# Altium schematic sheet Z12 - Neopixel Board V2.SchDoc("Z12 - Neopixel Board V2")
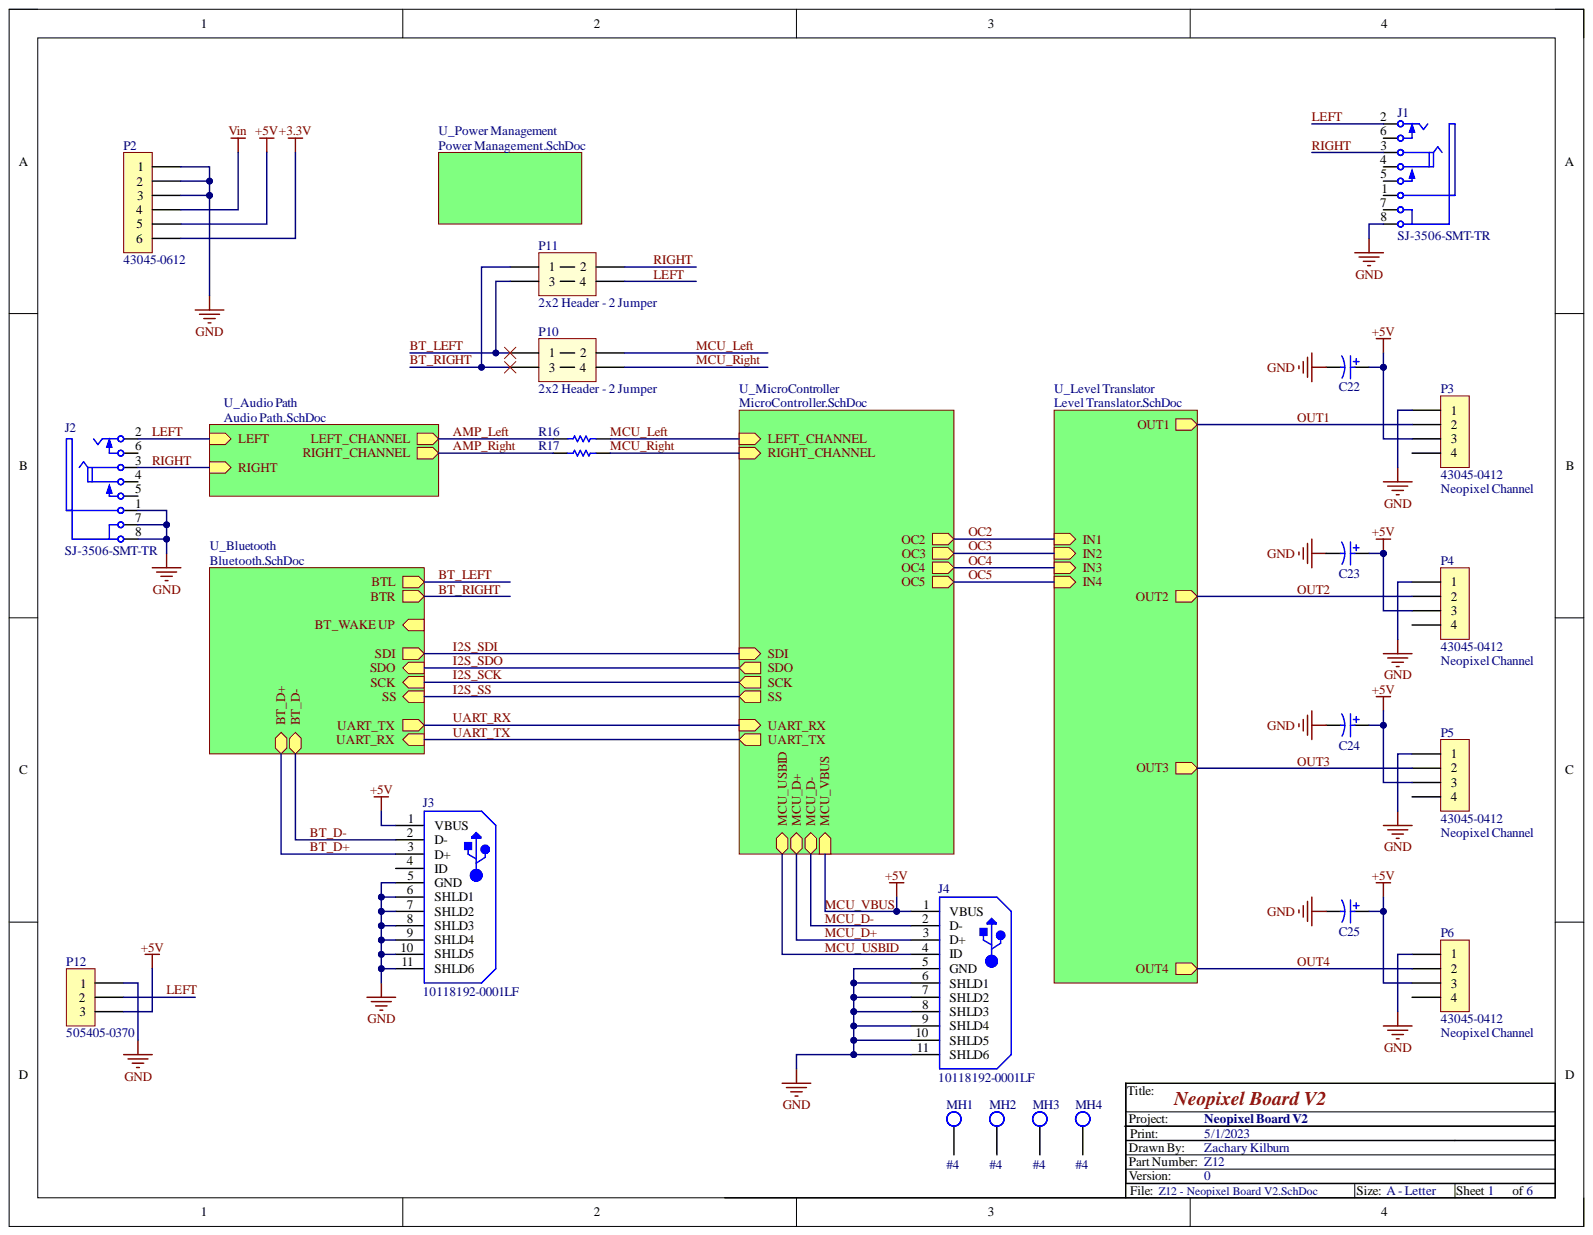
[diagram p0: Z12 - Neopixel Board V2.SchDoc]

## Parts
C22  T495D107K010ATE100  [PCC-KEMT-T495-D-RN]  (value not drawn)
C23  T495D107K010ATE100  [PCC-KEMT-T495-D-RN]  (value not drawn)
C24  T495D107K010ATE100  [PCC-KEMT-T495-D-RN]  (value not drawn)
C25  T495D107K010ATE100  [PCC-KEMT-T495-D-RN]  (value not drawn)
J1  SJ-3506-SMT-TR  [CUI-SJ-3506-SMT-TR_V]
J2  SJ-3506-SMT-TR  [CUI-SJ-3506-SMT-TR_V]
J3  10118192-0001LF  [FCI-10118192-0001LF-5_V]
J4  10118192-0001LF  [FCI-10118192-0001LF-5_V]
MH1  #4  [PCC-XXX-MH-#4-ROUND-PLATED-H128P230M290]  (no BOM)
MH2  #4  [PCC-XXX-MH-#4-ROUND-PLATED-H128P230M290]  (no BOM)
MH3  #4  [PCC-XXX-MH-#4-ROUND-PLATED-H128P230M290]  (no BOM)
MH4  #4  [PCC-XXX-MH-#4-ROUND-PLATED-H128P230M290]  (no BOM)
P2  43045-0612  [PCC-MOLX-43045-0612-R]
P3  43045-0412  Name: Neopixel Channel  [PCC-MOLX-43045-0412-R]
P4  43045-0412  Name: Neopixel Channel  [PCC-MOLX-43045-0412-R]
P5  43045-0412  Name: Neopixel Channel  [PCC-MOLX-43045-0412-R]
P6  43045-0412  Name: Neopixel Channel  [PCC-MOLX-43045-0412-R]
P10  2x2 Header - 2 Jumper  [2x2 PIN 0.1" - 2x Jumper]
P11  2x2 Header - 2 Jumper  [2x2 PIN 0.1" - 2x Jumper]
P12  505405-0370  [PCC-MOLX-505405-0370-R]
R16  Jumper 0603(1608)  [RESC0603(1608)_N]  (value not drawn)
R17  Jumper 0603(1608)  [RESC0603(1608)_N]  (value not drawn)

## Nets
+3.3V: P2.6
GND: C22.2 C23.2 C24.2 C25.2 J1.8 J2.1 J2.7 J2.8 J3.5(GND) J3.6(SHLD1) J3.7(SHLD2) J3.8(SHLD3) J3.9(SHLD4) J3.10(SHLD5) J3.11(SHLD6) J4.5(GND) J4.6(SHLD1) J4.7(SHLD2) J4.8(SHLD3) J4.9(SHLD4) J4.10(SHLD5) J4.11(SHLD6) P2.1 P2.2 P2.3 P3.1 P4.1 P5.1 P6.1 P12.1
Vin: P2.4
+5V: C22.1 C23.1 C24.1 C25.1 J3.1(VBUS) J4.1(VBUS) P2.5 P3.3 P4.3 P5.3 P6.3 P12.3
AMP_Left: R16.1
AMP_Right: R17.1
BT_D+: J3.3(D+)
BT_D-: J3.2(D-)
BT_LEFT: P10.1 P11.3
BT_RIGHT: P10.3 P11.1
LEFT: J1.2 J2.2 P11.4 P12.2
MCU_D+: J4.3(D+)
MCU_D-: J4.2(D-)
MCU_Left: P10.2 R16.2
MCU_Right: P10.4 R17.2
MCU_USBID: J4.4(ID)
OUT1: P3.2
OUT2: P4.2
OUT3: P5.2
OUT4: P6.2
RIGHT: J1.3 J2.3 P11.2
NetJ1_1: J1.1
NetJ1_4: J1.4
NetJ1_5: J1.5
NetJ1_6: J1.6
NetJ1_7: J1.7
NetJ2_4: J2.4
NetJ2_5: J2.5
NetJ2_6: J2.6
NetJ3_4: J3.4(ID)
NetMH1_1: MH1.1
NetMH2_1: MH2.1
NetMH3_1: MH3.1
NetMH4_1: MH4.1
NetP3_4: P3.4
NetP4_4: P4.4
NetP5_4: P5.4
NetP6_4: P6.4
BT_WAKE UP:
I2S_SCK:
I2S_SDI:
I2S_SDO:
I2S_SS:
OC2:
OC3:
OC4:
OC5:
UART_RX:
UART_TX:

## Library data of the parts
C22-C25 = CMP-000480-0: Capacitor, Tantalum, 100µF, 10V, SMD, Case Code D; Manufacturer: Kemet; PackingType: Cut Tape; Component Kind: Standard; Library Name: Capacitors.SchLib; PartNumber: T495D107K010ATE100
J1 J2 = CMP-1692-00003-1: Female Mid Mount Audio Jack, 6 Position, Height 2.55 mm, Tail Length 2 mm, -25 to 85 degC, RoHS, Tape and Reel; Current Rating: 7 A; Impedance: 50 Ohm; Case/Package: Axial; Component Kind: Standard; ComponentLink1Description: Manufacturer URL; ComponentLink1URL: http://www.cui.com/; ComponentLink2Description: Datasheet; ComponentLink2URL: http://www.cui.com/product/resource/sj-3506-smt.pdf; DatasheetVersion: Rev. 1.01, 11/2012; Diameter: 3.6 mm; Height: 3.6 mm; Length: 5 mm; Library Name: Altium Content Vault; Max Operating Temperature: 85degC; Min Operating Temperature: -40degC; Mount: Through Hole; Package Quantity: 500; PackageDescription: Female Mid Mount Audio Jack, 6 Position, Height 2.55 mm, Tail Length 2 mm; PackageReference: SJ-3506-SMT-TR; Packaging: Bulk; Pins: 2; Radiation Hardening: No; REACH SVHC: No SVHC; RoHSCompliant: True; Width: 3.6 mm
J3 J4 = CMP-2000-05118-1: Micro USB B Type Receptacle, -55 to 85 degC, 5-Pin SMD, RoHS, Tape and Reel; Brand: FCI; Connector Type: MicroUSBTypeBreceptacle; Current Rating: 1.8A; Gender: Female; Insulation Resistance: 1000MOhms; Mounting Angle: Right; Number of Contacts: 5Contact; Color: Black; Component Kind: Standard; Contact Material: CopperAlloy; Contact Plating: Gold; Datasheet URL: http://www.mouser.com/ds/2/154/10118192-793027.pdf; Datasheet Version: Rev. B, 05/2015; Factory Pack Quantity: 1400; Flammability Rating: UL94V-0; Library Name: Altium Content Vault; Manufacturer: FCI; Manufacturer URL: http://www.fci.com/; Operating Temperature Range: -55Cto+85C; Packaging: Reel; Product: MicroUSBTypeBConnectors; Product Category: USBConnectors; RoHS: Details; Standard: USB2.0; Termination Style: SMD/SMT
MH1-MH4 = CMP-000163-2: #4 Mounting Hole, Round, Plated, No Vias; Units: Imperial; Library Name: MountingHoleImperial.SchLib; Size: #4
P2 = CMP-000056-0: Molex Micro-Fit 3.0 Connector, Dual-Row, 6-Position, Vertical, 3mm Pitch; Current: 5A; MatingStyle: Plug; Positions: 6; Rows: 2; Voltage: 250V; Component Kind: Standard; ComponentLink1Description: Manufacturer URL; ComponentLink1URL: http://www.molex.com; ComponentLink2Description: Product URL; ComponentLink2URL: http://www.molex.com/molex/products/datasheet.jsp?part=active/0430450612_PCB_HEADERS.xml; ComponentLink3Description: Datasheet; ComponentLink3URL: http://www.molex.com/pdm_docs/ps/PS-43045.pdf; ComponentLink4Description: Package Specification; ComponentLink4URL: http://www.molex.com/pdm_docs/sd/430450612_sd.pdf; HelpURL: http://www.molex.com/pdm_docs/sd/430450612_sd.pdf; Library Name: Connectors.SchLib; Manufacturer: Molex; MountingTechnology: Through Hole; OperatingTemperature: -40C to +105C; Orientation: Vertical; PackageName: Micro-Fit 3.0; PartNumber: 43045-0612; Pitch: 3mm; RoHS: Yes; StorageTemperature: -40C to +105C
P3-P6 = CMP-000027-0: Molex Micro-Fit 3.0 Connector, Dual-Row, 4-Position, Vertical, 3mm Pitch; Current: 5A; MatingStyle: Plug; Positions: 4; Rows: 2; Voltage: 250V; Component Kind: Standard; ComponentLink1Description: Manufacturer URL; ComponentLink1URL: http://www.molex.com; ComponentLink2Description: Product URL; ComponentLink2URL: http://www.molex.com/molex/products/datasheet.jsp?part=active/0430450412_PCB_HEADERS.xml; ComponentLink3Description: Datasheet; ComponentLink3URL: http://www.molex.com/pdm_docs/ps/PS-43045.pdf; ComponentLink4Description: Package Specification; ComponentLink4URL: http://www.molex.com/pdm_docs/sd/430450412_sd.pdf; HelpURL: http://www.molex.com/pdm_docs/sd/430450412_sd.pdf; Library Name: Molex-Micro-Fit.SchLib; Manufacturer: Molex; MountingTechnology: Through Hole; OperatingTemperature: -40C to +105C; Orientation: Vertical; PackageName: Micro-Fit 3.0; PartNumber: 43045-0412; Pitch: 3mm; RoHS: Yes; StorageTemperature: -40C to +105C
P12 = CMP-000030-0: Molex CLIK-Mate Series Connector, Single-Row, 3-Position, Vertical, 1.5mm Pitch; Current: 2A; MatingStyle: Plug; PackingType: Reel; Positions: 3; Rows: 1; Voltage: 100V; Component Kind: Standard; ComponentLink1Description: Manufacturer URL; ComponentLink1URL: http://www.molex.com; ComponentLink2Description: Product URL; ComponentLink2URL: http://www.molex.com/molex/products/datasheet.jsp?part=active/5054050370_PCB_RECEPTACLES.xml; ComponentLink3Description: Datasheet; ComponentLink3URL: http://www.molex.com/pdm_docs/ps/PS-505405-001.pdf; ComponentLink4Description: Package Specification; ComponentLink4URL: http://www.molex.com/pdm_docs/sd/5054050370_sd.pdf; HelpURL: http://www.molex.com/pdm_docs/sd/5054050370_sd.pdf; Manufacturer: Molex; MountingTechnology: Surface Mount; OperatingTemperature: -40C to +105C; Orientation: Vertical; PackageName: CLIK-Mate; PartNumber: 505405-0370; Pitch: 1.5mm; RoHS: Yes; StorageTemperature: +5C to +35C; Library Name: IEC Primary Vault
R16 R17 = CMP-1012-00001-1: Jumper 0603 (1608 Metric); Case-EIA: 0603; Case-Metric: 1608; Power: None; Value: 0.00 Ohm; Component Kind: Standard; Library Name: Altium Content Vault; Technology: SMT; Tolerance: None
P10 P11 = 2x2 Header - 2 Jumper: Component Kind: Standard; Library Name: Headers.SchLib
